# Altium schematic sheet nanoCH32V203.SchDoc
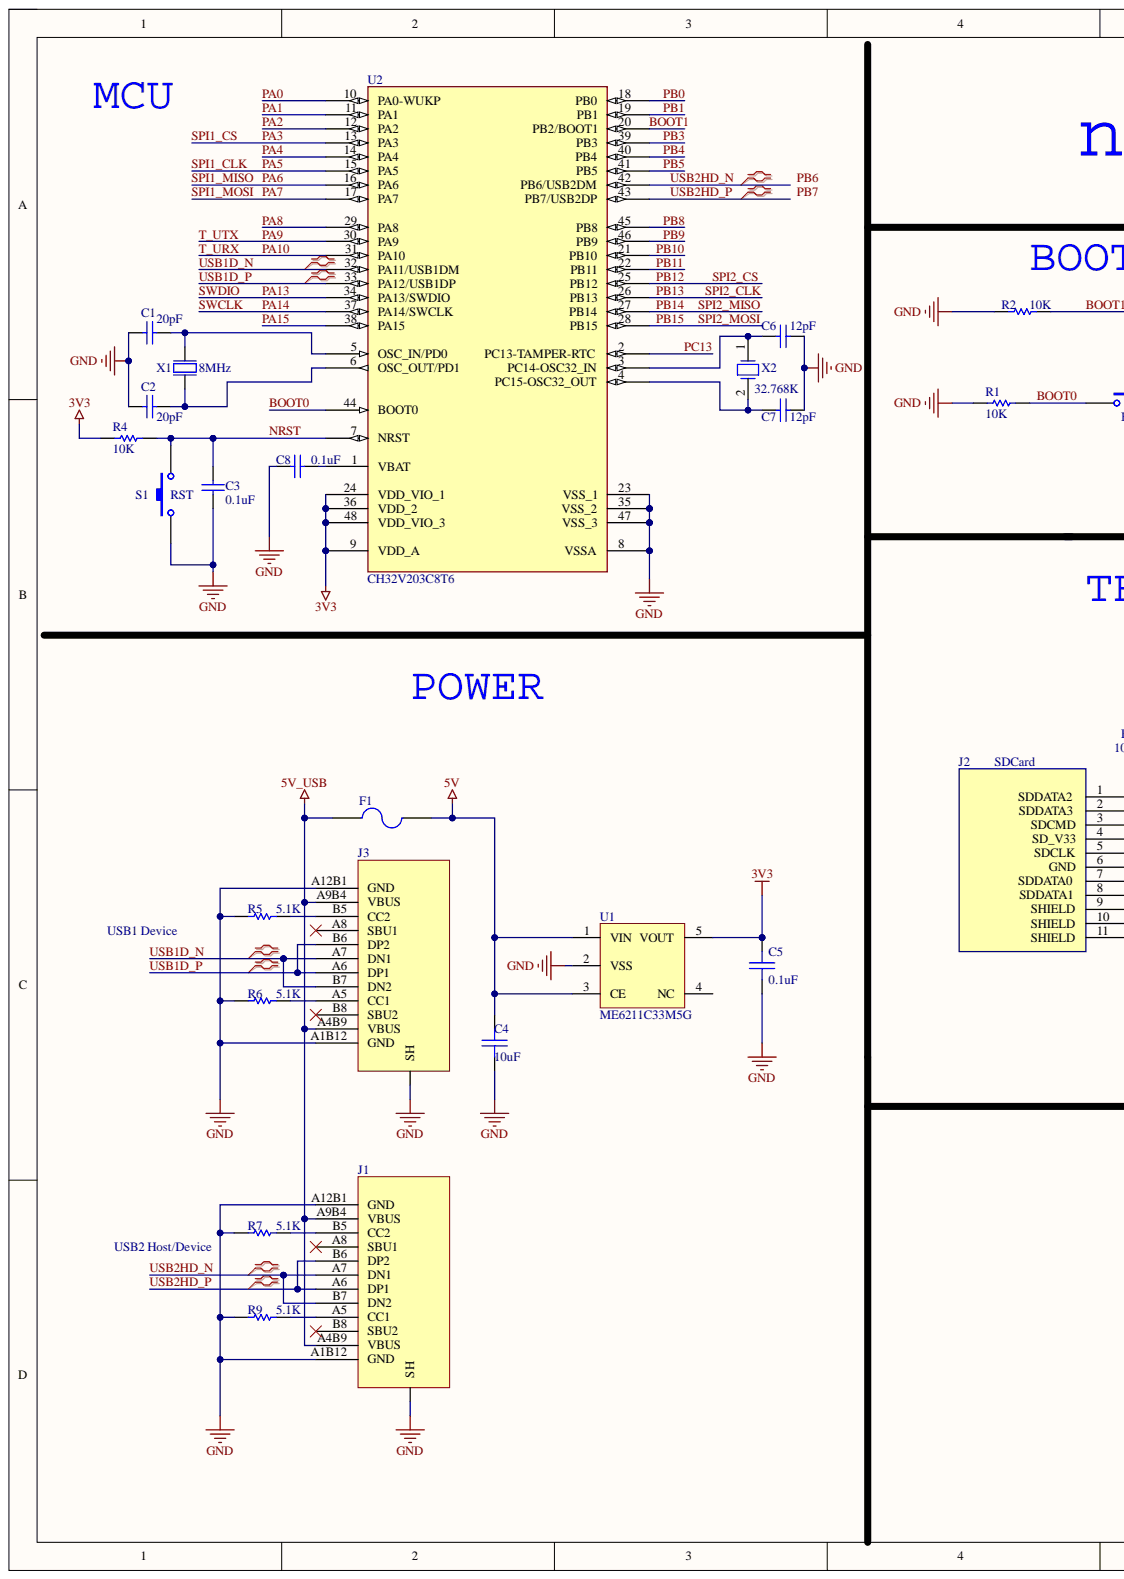
[diagram p0: nanoCH32V203.SchDoc, left]
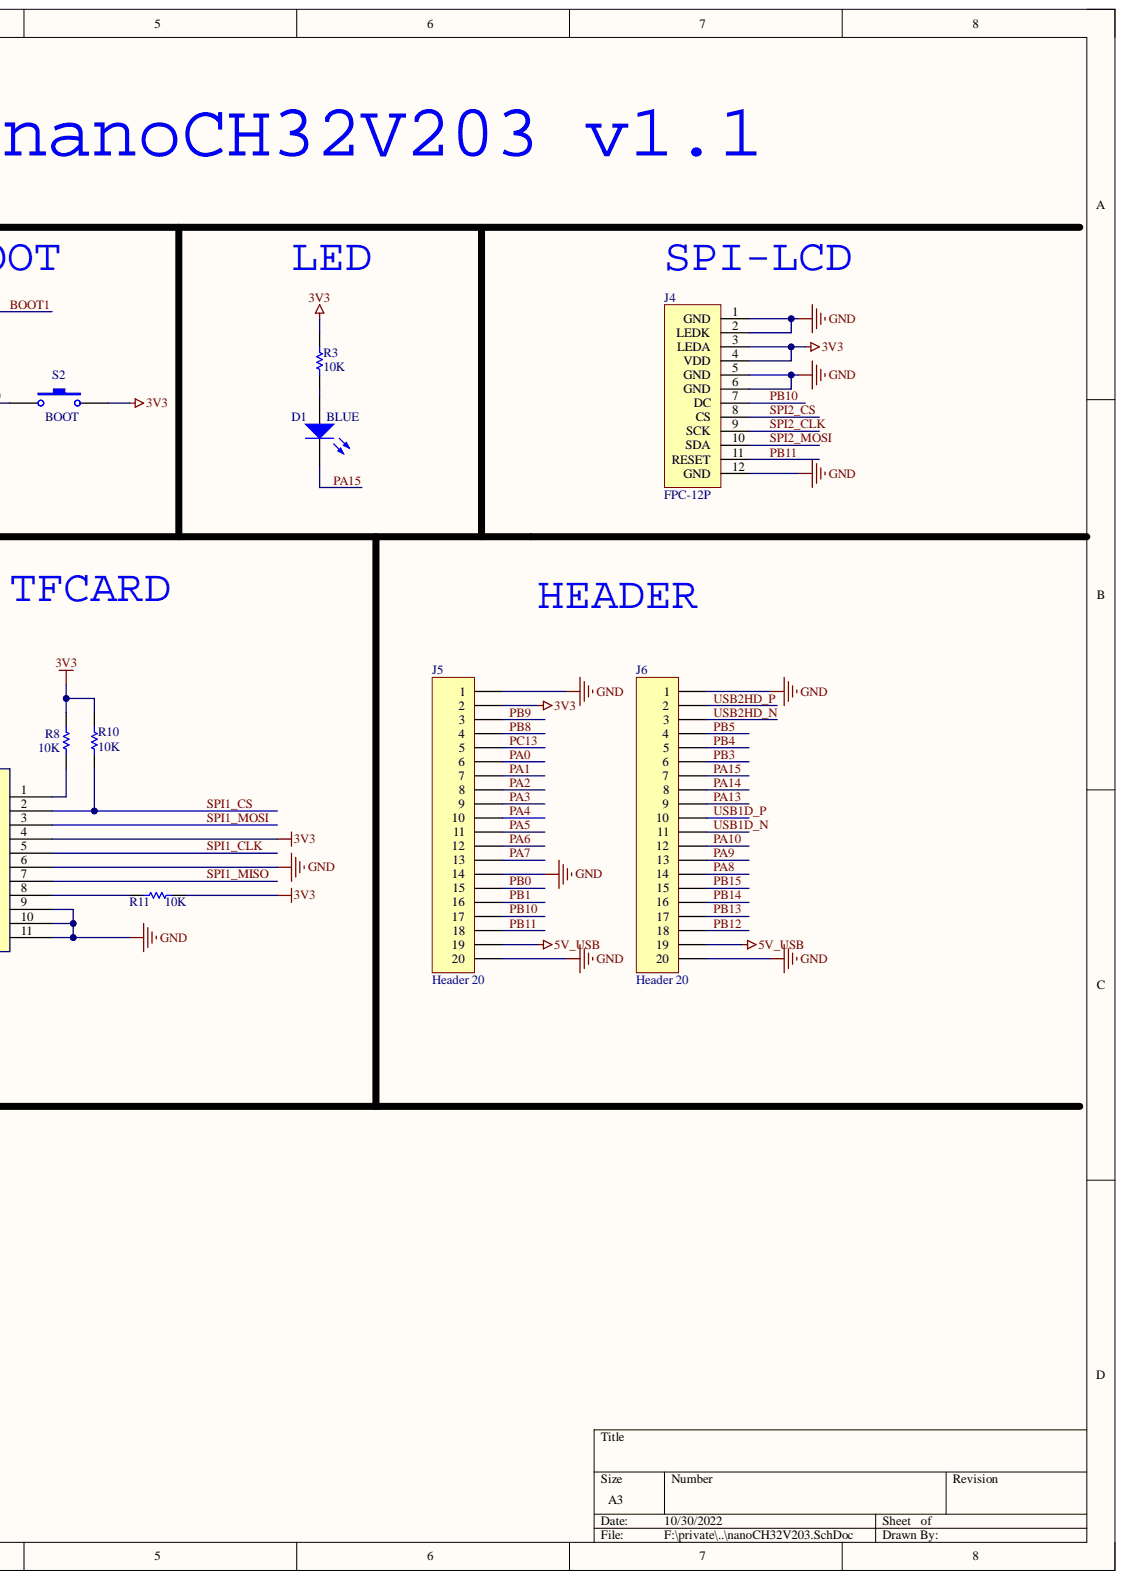
[diagram p1: nanoCH32V203.SchDoc, right]

## Parts
C1  20pF  [C 0402_M_new]
C2  20pF  [C 0402_M_new]
C3  0.1uF  [C 0402_M_new]
C4  10uF  [C 0402_M_new]
C5  0.1uF  [C 0402_M_new]
C6  12pF  [C 0402_M_new]
C7  12pF  [C 0402_M_new]
C8  0.1uF  [C 0402_M_new]
D1  BLUE  [0603_LED_B]
F1  Fuse 2  [FU 0805]  (value not drawn)
J1  Type_C_16P  [Type_C_16P]  (value not drawn)
J2  SDCard  [SDCARD-1]
J3  Type_C_16P  [Type_C_16P]  (value not drawn)
J4  FPC-12P  [FPC0.5 2H-WS-12P]
J5  Header 20  [HEADER_20x1]
J6  Header 20  [HEADER_20x1]
R1  10K  [R 0402_M_new]
R2  10K  [R 0402_M_new]
R3  10K  [R 0402_M_new]
R4  10K  [R 0402_M_new]
R5  5.1K  [R 0402_M_new]
R6  5.1K  [R 0402_M_new]
R7  5.1K  [R 0402_M_new]
R8  10K  [R 0402_M_new]
R9  5.1K  [R 0402_M_new]
R10  10K  [R 0402_M_new]
R11  10K  [R 0402_M_new]
S1  RST  [SMT_SW_PTS_820]
S2  BOOT  [SMT_SW_PTS_820]
U1  ME6211C33M5G  [SOT23-5]
U2  CH32V203C8T6  [LQFP48_L]
X1  8MHz  [BT-XTAL_3225]
X2  32.768K  [OSC 3215-2P]

## Nets
3V3: C5.1 J2.4(SD_V33) J4.3(LEDA) J4.4(VDD) J5.2 R3.1 R4.2 R8.1 R10.1 R11.1 S2.2 U1.5(VOUT) U2.9(VDD_A) U2.24(VDD_VIO_1) U2.36(VDD_2) U2.48(VDD_VIO_3)
5V: C4.1 F1.2 U1.1(VIN) U1.3(CE)
5V_USB: F1.1 J1.A4B9(VBUS) J1.A9B4(VBUS) J3.A4B9(VBUS) J3.A9B4(VBUS) J5.19 J6.19
GND: C1.2 C2.2 C3.1 C4.2 C5.2 C6.2 C7.2 C8.2 J1.0(SH) J1.A1B12(GND) J1.A12B1(GND) J2.6(GND) J2.9(SHIELD) J2.10(SHIELD) J2.11(SHIELD) J3.0(SH) J3.A1B12(GND) J3.A12B1(GND) J4.1(GND) J4.2(LEDK) J4.5(GND) J4.6(GND) J4.12(GND) J5.1 J5.14 J5.20 J6.1 J6.20 R1.1 R2.2 R5.1 R6.1 R7.1 R9.1 S1.1 U1.2(VSS) U2.8(VSSA) U2.23(VSS_1) U2.35(VSS_2) U2.47(VSS_3) X1.2 X1.4
BOOT0: R1.2 S2.1 U2.44(BOOT0)
BOOT1: R2.1 U2.20(PB2/BOOT1)
NRST: C3.2 R4.1 S1.2 U2.7(NRST)
PA0: J5.6 U2.10(PA0-WUKP)
PA1: J5.7 U2.11(PA1)
PA2: J5.8 U2.12(PA2)
PA3: J2.2(SDDATA3) J5.9 R10.2 U2.13(PA3)
PA4: J5.10 U2.14(PA4)
PA5: J2.5(SDCLK) J5.11 U2.15(PA5)
PA6: J2.7(SDDATA0) J5.12 U2.16(PA6)
PA7: J2.3(SDCMD) J5.13 U2.17(PA7)
PA8: J6.14 U2.29(PA8)
PA9: J6.13 U2.30(PA9)
PA10: J6.12 U2.31(PA10)
PA13: J6.9 U2.34(PA13/SWDIO)
PA14: J6.8 U2.37(PA14/SWCLK)
PA15: D1.2 J6.7 U2.38(PA15)
PB0: J5.15 U2.18(PB0)
PB1: J5.16 U2.19(PB1)
PB3: J6.6 U2.39(PB3)
PB4: J6.5 U2.40(PB4)
PB5: J6.4 U2.41(PB5)
PB8: J5.4 U2.45(PB8)
PB9: J5.3 U2.46(PB9)
PB10: J4.7(DC) J5.17 U2.21(PB10)
PB11: J4.11(RESET) J5.18 U2.22(PB11)
PB12: J4.8(CS) J6.18 U2.25(PB12)
PB13: J4.9(SCK) J6.17 U2.26(PB13)
PB14: J6.16 U2.27(PB14)
PB15: J4.10(SDA) J6.15 U2.28(PB15)
PC13: J5.5 U2.2(PC13-TAMPER-RTC)
USB1D_N: J3.A7(DN1) J3.B7(DN2) J6.11 U2.32(PA11/USB1DM)
USB1D_P: J3.A6(DP1) J3.B6(DP2) J6.10 U2.33(PA12/USB1DP)
USB2HD_N: J1.A7(DN1) J1.B7(DN2) J6.3 U2.42(PB6/USB2DM)
USB2HD_P: J1.A6(DP1) J1.B6(DP2) J6.2 U2.43(PB7/USB2DP)
NetC1_1: C1.1 U2.5(OSC_IN/PD0) X1.1
NetC2_1: C2.1 U2.6(OSC_OUT/PD1) X1.3
NetC6_1: C6.1 U2.3(PC14-OSC32_IN) X2.1
NetC7_1: C7.1 U2.4(PC15-OSC32_OUT) X2.2
NetC8_1: C8.1 U2.1(VBAT)
NetD1_1: D1.1 R3.2
NetJ1_A5: J1.A5(CC1) R9.2
NetJ1_B5: J1.B5(CC2) R7.2
NetJ2_1: J2.1(SDDATA2) R8.2
NetJ2_8: J2.8(SDDATA1) R11.2
NetJ3_A5: J3.A5(CC1) R6.2
NetJ3_B5: J3.B5(CC2) R5.2
PB6:
PB7:

Not connected: J1 A8(SBU1) B8(SBU2); J3 A8(SBU1) B8(SBU2); U1 4(NC)

## Library data of the parts
C1-C3 C6-C8 = C0603: Capacitor; Component Kind: Standard; LatestRevisionDate: 17-Jul-2002; LatestRevisionNote: Re-released for DXP Platform.; Library Name: MYLIB.IntLib; PackageDescription: Radial Cap, Thru-Hole; 2 Leads; 0.3 in Pin Spacing; PackageReference: RAD-0.3; Published: 8-Jun-2000; Signal Integrity: Cap; Simulation: CAP; Publisher: Altium Limited
  C1 C2: Value: 20pF
  C3 C8: Value: 0.1uF
  C6 C7: Value: 12pF
C4 = SMT_C_0805: Surface mount capacitor 0805; Component Kind: Standard; Library Name: Vowstar_Basic_Device.IntLib
C5 = SMT_C_0402: Surface mount capacitor 0402; Component Kind: Standard; Library Name: Vowstar_Basic_Device.IntLib
D1 = D0603: Typical RED, GREEN, YELLOW, AMBER GaAs LED; Component Kind: Standard; LatestRevisionDate: 17-Jul-2002; LatestRevisionNote: Re-released for DXP Platform.; Library Name: MYLIB.IntLib; PackageDescription: SM LED; 2 Flat Leads; PackageReference: 3.2X1.6X1.1; PackageVersion: Feb-2002; Published: 8-Jun-2000; Simulation: LED2; Publisher: Altium Limited
F1 = Fuse 2: Fuse; Component Kind: Standard; LatestRevisionDate: 17-Jul-2002; LatestRevisionNote: Re-released for DXP Platform.; Library Name: Miscellaneous Devices.IntLib; PackageDescription: Thru-Hole; 2 Leads; PackageReference: PIN-W2/E2.8; Published: 8-Jun-2000; Simulation: FUSE; Publisher: Altium Limited
R1-R11 = R0603: Resistor; Component Kind: Standard; LatestRevisionDate: 17-Jul-2002; LatestRevisionNote: Re-released for DXP Platform.; Library Name: MYLIB.IntLib; PackageDescription: Axial Device, Thru-Hole; 2 Leads; 0.3 in Pin Spacing; PackageReference: AXIAL-0.3; Published: 8-Jun-2000; Signal Integrity: Res; Simulation: RESISTOR; Publisher: Altium Limited
  R1-R4 R8 R10 R11: Value: 10K
  R5-R7 R9: Value: 5.1K
S1 S2 = SW-PB: Switch; Component Kind: Standard; LatestRevisionDate: 17-Jul-2002; LatestRevisionNote: Re-released for DXP Platform.; Library Name: Miscellaneous Devices.IntLib; PackageDescription: Switch; 2 Leads; PackageReference: SPST-2; Published: 24-Mar-1999; Publisher: Altium Limited
X1 = XTAL SMD 12MHz (3225): XTAL SMD 3225, 8MHz, 18pF, ±30ppm; 2nd source part number: 184-2056; Manufacturer: TXC Corporation; Manufacturer Part Number: 7M-12.000MAAJ-T; Artikkelnr Noca: 22019166; Artikkelnr Simpro: N.A.; Database Table Name: Crystal_Osc$; Note: XTAL SMD 12.000MHz; 2nd source Supplier: Farnell; Component Kind: Standard; Datasheet: http://www.txccrystal.com/images/pdf/7m.pdf; Footprint Doc: BT-XTAL_3225; LatestRevisionDate: 2010-08-31; Library Name: Nordic Discrete DB.DbLib; Part Number: XTAL SMD 12MHz (3225); Pin Count: 4; Published: 2010-08-31; Supplier: Digi-Key; Supplier part number: 887-1121-1-ND; Value: 8MHz; Publisher: Nordic Semiconductor
X2 = XTAL: Component Kind: Standard; Library Name: nanoCH32V103.SCHLIB
J1 J3 = Type_C_16P: Component Kind: Standard; Library Name: ICESugar.SCHLIB
J2 = SDCard: Component Kind: Standard; Library Name: ICESugar-Pro.SCHLIB
J4 = FPC-12P: Component Kind: Standard; Library Name: nanoCH32V203.SCHLIB
J5 J6 = Header 20: Component Kind: Standard; Library Name: nanoSTM32.SCHLIB
U1 = ME6211C33M5G: Component Kind: Standard
U2 = CH32V203C8T6: Component Kind: Standard; Library Name: nanoCH32V203.SCHLIB
